# Altium schematic sheet AD7124_AD8659ARZ_edel_402_v1.SchDoc(AD7124_AD8659ARZ_edel_402_v1)
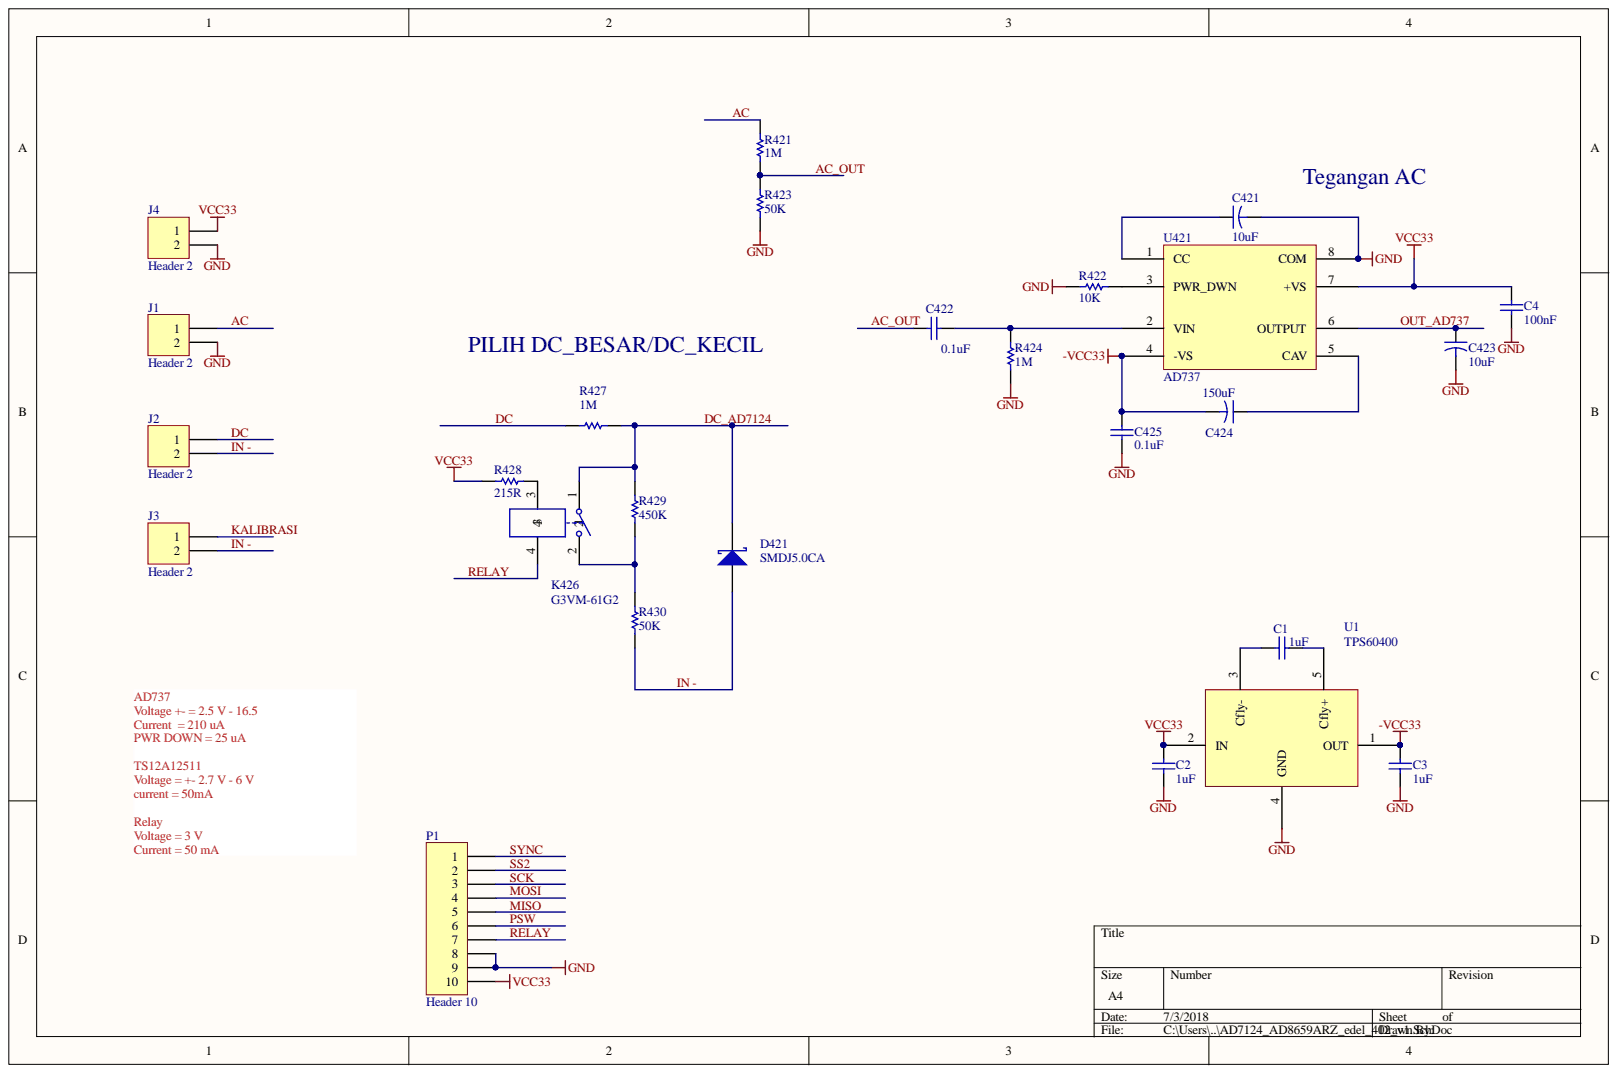
[diagram p0: AD7124_AD8659ARZ_edel_402_v1.SchDoc]

## Parts
C1  1uF  [0805]
C2  1uF  [0805]
C3  1uF  [0805]
C4  100nF  [0805]
C421  10uF  [CAPR5-4X5]
C422  0.1uF  [0805]
C423  10uF  [CAPR5-4X5]
C424  150uF  [cap220uf]
C425  0.1uF  [0805]
D421  SMDJ5.0CA  [SMDJ5.0]
J1  Header 2  [MSTBA2]
J2  Header 2  [MSTBA2]
J3  Header 2  [MSTBA2]
J4  Header 2  [MSTBA2]
K426  G3VM-61G2  [G3VM-61G2]
P1  Header 10  [HDR1X10]
R421  1M  [0805]
R422  10K  [0805]
R423  50K  [0805]
R424  1M  [0805]
R427  1M  [0805]
R428  215R  [0805]
R429  450K  [0805]
R430  50K  [0805]
U1  TPS60400  [TPS60400]
U421  AD737  [AD737JRZ-5]

## Nets
-VCC33: C3.2 C424.2 C425.2 U1.1(OUT) U421.4(-VS)
GND: C2.1 C3.1 C4.1 C421.2 C423.2 C425.1 J1.2 J4.2 P1.8 P1.9 R422.2 R423.1 R424.1 U1.4(GND) U421.8(COM)
VCC33: C2.2 C4.2 J4.1 P1.10 R428.2 U1.2(IN) U421.7(+VS)
AC: J1.1 R421.2
AC_OUT: C422.1 R421.1 R423.2
DC: J2.1 R427.1
DC_AD7124: D421.2 K426.1 R427.2 R429.2
IN -: D421.1 J2.2 J3.2 R430.1
KALIBRASI: J3.1
MISO: P1.5
MOSI: P1.4
OUT_AD737: C423.1 U421.6(OUTPUT)
PSW: P1.6
RELAY: K426.4 P1.7
SCK: P1.3
SS2: P1.2
SYNC: P1.1
NetC1_1: C1.1 U1.3(Cfly-)
NetC1_2: C1.2 U1.5(Cfly+)
NetC421_1: C421.1 U421.1(CC)
NetC422_2: C422.2 R424.2 U421.2(VIN)
NetC424_1: C424.1 U421.5(CAV)
NetK426_2: K426.2 R429.1 R430.2
NetK426_3: K426.3 R428.1
NetR422_1: R422.1 U421.3(PWR_DWN)

## Library data of the parts
C1-C4 C422 C425 = Cap: Capacitor; Simulation: CAP; Signal Integrity: Cap; Published: 8-Jun-2000; PackageReference: RAD-0.3; PackageDescription: Radial Cap, Thru-Hole; 2 Leads; 0.3 in Pin Spacing; Library Name: Miscellaneous Devices.IntLib; LatestRevisionNote: Re-released for DXP Platform.; LatestRevisionDate: 17-Jul-2002; Component Kind: Standard; Publisher: Altium Limited
  C1-C3: Value: 1uF
  C4: Value: 100nF
  C422 C425: Value: 0.1uF
C421 C423 C424 = Cap2: Capacitor; Simulation: CAP; Signal Integrity: Cap; Published: 23-Sep-2002; PackageReference: CAPR5-4X5; PackageDescription: Capacitor; 2 Leads; Library Name: Miscellaneous Devices.IntLib; Component Kind: Standard; Publisher: Altium Limited
  C421 C423: Value: 10uF
  C424: Value: 150uF
D421 = D Schottky: Schottky Diode; Simulation: SKYDIODE; Signal Integrity: D Schottky; Published: 8-Jun-2000; PackageReference: SMB; PackageDescription: DO-214-AA/SMB; 2 C-Bend Leads; Body 5.3 x 3.6 mm, inc. leads (LxW); Library Name: Miscellaneous Devices.IntLib; LatestRevisionNote: Re-released for DXP Platform.; LatestRevisionDate: 17-Jul-2002; Component Kind: Standard; Code_JEDEC: DO-214-AA; Publisher: Altium Limited
J1-J4 = Header 2: Header, 2-Pin; Signal Integrity: Connector; Library Name: Miscellaneous Connectors.IntLib; LatestRevisionNote: Re-released for DXP Platform.; LatestRevisionDate: 17-Jul-2002; Component Kind: Standard; Publisher: Altium Limited
K426 = Relay-SPST: Single-Pole Single-Throw Relay; Simulation: SPSTRELAY; Published: 24-Mar-1999; PackageReference: MODULE4; Library Name: Miscellaneous Devices.IntLib; LatestRevisionNote: Re-released for DXP Platform.; LatestRevisionDate: 17-Jul-2002; Component Kind: Standard; Publisher: Altium Limited
P1 = Header 10: Header, 10-Pin; Signal Integrity: Connector; Library Name: Miscellaneous Connectors.IntLib; LatestRevisionNote: Re-released for DXP Platform.; LatestRevisionDate: 17-Jul-2002; Component Kind: Standard; Publisher: Altium Limited
R421-R424 R427-R430 = Res1: Resistor; Simulation: RESISTOR; Signal Integrity: Res; Published: 8-Jun-2000; PackageReference: AXIAL-0.3; PackageDescription: Axial Device, Thru-Hole; 2 Leads; 0.3 in Pin Spacing; Library Name: Miscellaneous Devices.IntLib; LatestRevisionNote: Re-released for DXP Platform.; LatestRevisionDate: 17-Jul-2002; Component Kind: Standard; Publisher: Altium Limited
  R421 R424 R427: Value: 1M
  R422: Value: 10K
  R423 R430: Value: 50K
  R428: Value: 215R
  R429: Value: 450K
U1 = TPS60400: Library Name: SmartTB.SchLib; Component Kind: Standard
U421 = AD737: Library Name: SmartTB.SchLib; Component Kind: Standard
